# Altium schematic sheet aiao.SchDoc(aiao)
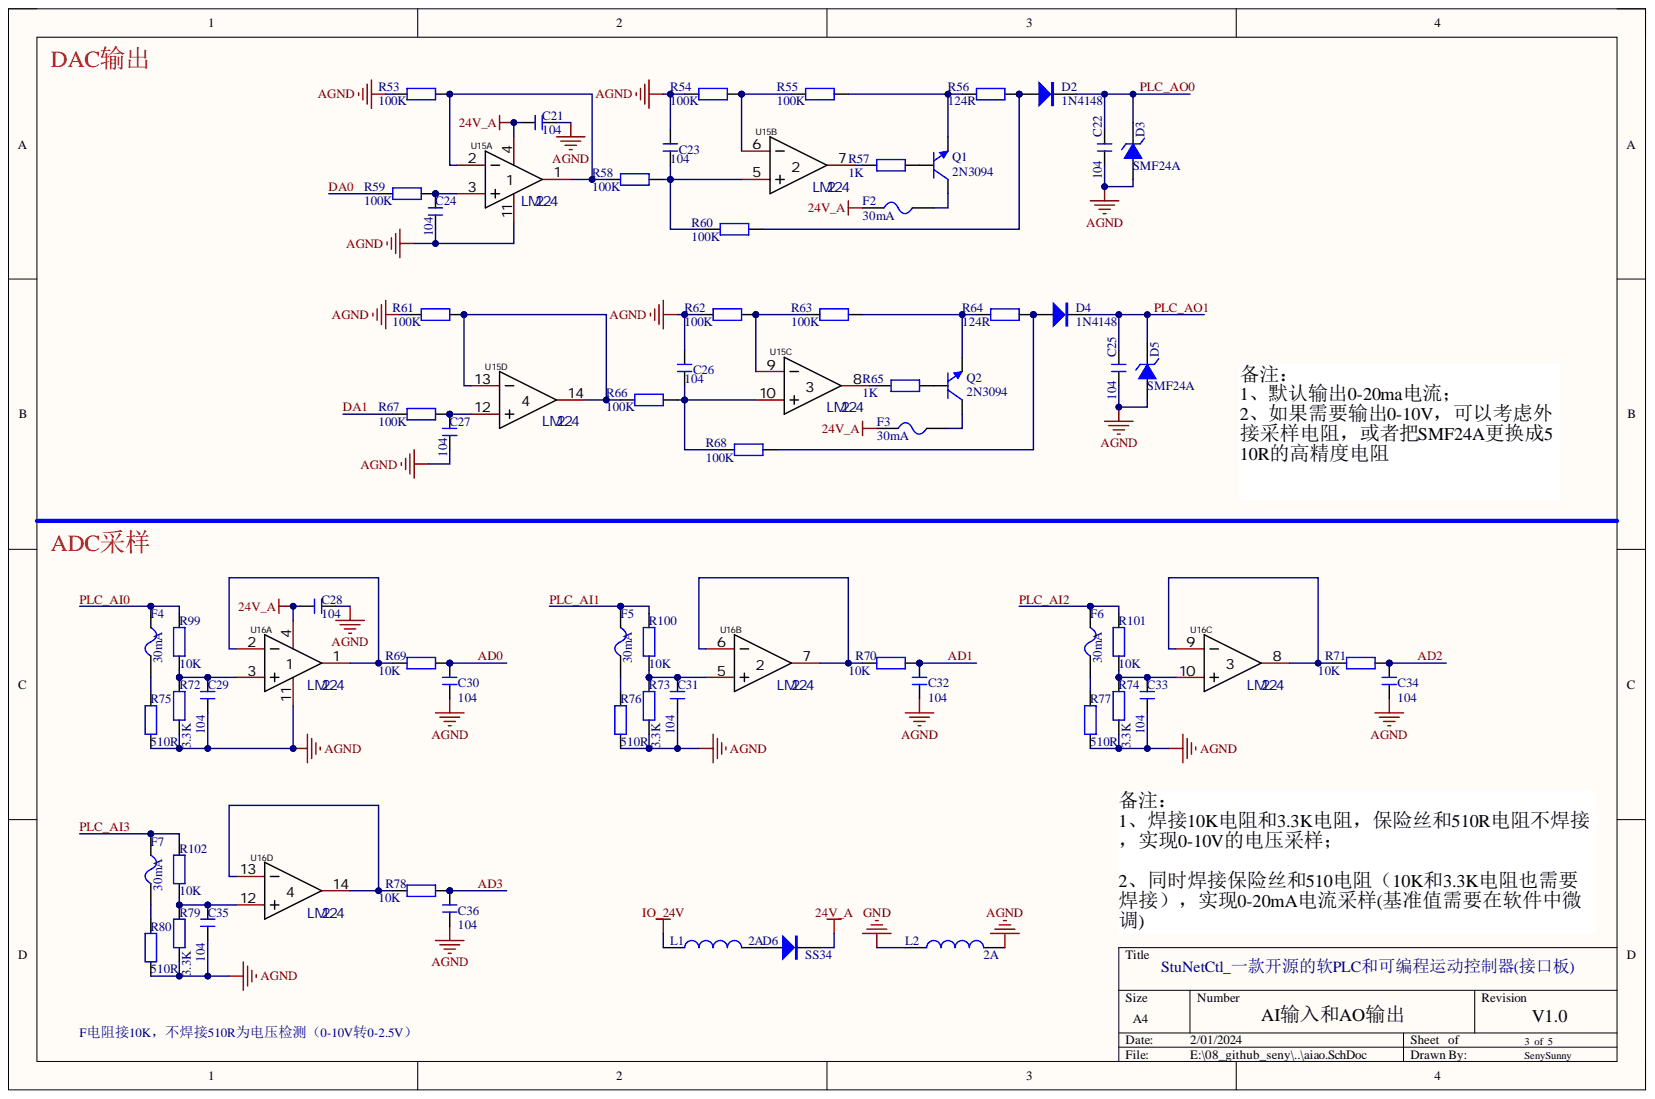
[diagram p0: aiao.SchDoc]

## Parts
C21  104  [C 0603]
C22  104  [C 0603]
C23  104  [C 0603]
C24  104  [C 0603]
C25  104  [C 0603]
C26  104  [C 0603]
C27  104  [C 0603]
C28  104  [C 0603]
C29  104  [C 0603]
C30  104  [C 0603]
C31  104  [C 0603]
C32  104  [C 0603]
C33  104  [C 0603]
C34  104  [C 0603]
C35  104  [C 0603]
C36  104  [C 0603]
D2  1N4148  [SOD-123F]
D3  SMF24A  [SOD-123]
D4  1N4148  [SOD-123F]
D5  SMF24A  [SOD-123]
D6  SS34  [SMA(DO214AC)]
F2  30mA  [FU 0805]
F3  30mA  [FU 0805]
F4  30mA  [FU 0805]
F5  30mA  [FU 0805]
F6  30mA  [FU 0805]
F7  30mA  [FU 0805]
L1  2A  [L 0805]
L2  2A  [L 0805]
Q1  2N3094  [SOT23-3N]
Q2  2N3094  [SOT23-3N]
R53  100K  [R 0603]
R54  100K  [R 0603]
R55  100K  [R 0603]
R56  124R  [R 1206]
R57  1K  [R 0603]
R58  100K  [R 0603]
R59  100K  [R 0603]
R60  100K  [R 0603]
R61  100K  [R 0603]
R62  100K  [R 0603]
R63  100K  [R 0603]
R64  124R  [R 1206]
R65  1K  [R 0603]
R66  100K  [R 0603]
R67  100K  [R 0603]
R68  100K  [R 0603]
R69  10K  [R 0603]
R70  10K  [R 0603]
R71  10K  [R 0603]
R72  3.3K  [R 0603]
R73  3.3K  [R 0603]
R74  3.3K  [R 0603]
R75  510R  [R 1206]
R76  510R  [R 1206]
R77  510R  [R 1206]
R78  10K  [R 0603]
R79  3.3K  [R 0603]
R80  510R  [R 1206]
R99  10K  [R 0603]
R100  10K  [R 0603]
R101  10K  [R 0603]
R102  10K  [R 0603]
U15  LM224  [SOP14_L]  (parts A,B,C,D)
U16  LM224  [SOP14_L]  (parts A,B,C,D)

## Nets
24V_A: C21.1 C28.1 D6.2 F2.2 F3.2 U15.4 U16.4
AGND: C21.2 C22.2 C23.1 C24.1 C25.2 C26.1 C27.1 C28.2 C29.1 C30.1 C31.1 C32.1 C33.1 C34.1 C35.1 C36.1 D3.1 D5.1 L2.2 R53.1 R54.1 R61.1 R62.1 R72.2 R73.2 R74.2 R75.2 R76.2 R77.2 R79.2 R80.2 U15.11 U16.11
GND: L2.1
IO_24V: L1.1
AD0: C30.2 R69.2
AD1: C32.2 R70.2
AD2: C34.2 R71.2
AD3: C36.2 R78.2
DA0: R59.1
DA1: R67.1
PLC_AI0: F4.2 R99.1
PLC_AI1: F5.2 R100.1
PLC_AI2: F6.2 R101.1
PLC_AI3: F7.2 R102.1
PLC_AO0: C22.1 D2.2 D3.2
PLC_AO1: C25.1 D4.2 D5.2
NetC23_2: C23.2 R58.2 R60.1 U15.5
NetC24_2: C24.2 R59.2 U15.3
NetC26_2: C26.2 R66.2 R68.1 U15.10
NetC27_2: C27.2 R67.2 U15.12
NetC29_2: C29.2 R72.1 R99.2 U16.3
NetC31_2: C31.2 R73.1 R100.2 U16.5
NetC33_2: C33.2 R74.1 R101.2 U16.10
NetC35_2: C35.2 R79.1 R102.2 U16.12
NetD2_1: D2.1 R56.2 R60.2
NetD4_1: D4.1 R64.2 R68.2
NetD6_1: D6.1 L1.2
NetF2_1: F2.1 Q1.3
NetF3_1: F3.1 Q2.3
NetF4_1: F4.1 R75.1
NetF5_1: F5.1 R76.1
NetF6_1: F6.1 R77.1
NetF7_1: F7.1 R80.1
NetQ1_1: Q1.1 R57.2
NetQ1_2: Q1.2 R55.2 R56.1
NetQ2_1: Q2.1 R65.2
NetQ2_2: Q2.2 R63.2 R64.1
NetR53_2: R53.2 R58.1 U15.1 U15.2
NetR54_2: R54.2 R55.1 U15.6
NetR57_1: R57.1 U15.7
NetR61_2: R61.2 R66.1 U15.13 U15.14
NetR62_2: R62.2 R63.1 U15.9
NetR65_1: R65.1 U15.8
NetR69_1: R69.1 U16.1 U16.2
NetR70_1: R70.1 U16.6 U16.7
NetR71_1: R71.1 U16.8 U16.9
NetR78_1: R78.1 U16.13 U16.14

## Library data of the parts
C21-C36 = C: 无极性贴片电容; 版权归属: 源创客; BOM名称: 无极性贴片电容; Component Kind: Standard; 学习资源: www.ooocke.com; Library Name: zblib_v1.IntLib
D2 D4 = FFM107: 快恢复整流二极管; BOM名称: 快恢复二极管; 版权归属: 源创客; Component Kind: Standard; 学习资源: www.ooocke.com; Library Name: zblib_v1.IntLib
D3 D5 = SMF6.0A: TVS二极管/SMF6.0A; BOM名称: 5.1V/1W稳压管; 版权归属: 源创客; Component Kind: Standard; 学习资源: www.ooocke.com; Library Name: zblib_v1.IntLib
D6 = SS14: 肖特基二极管; 属性: 40V/1A; 版权归属: 源创客; BOM名称: 肖特基二极管; Component Kind: Standard; 学习资源: www.ooocke.com; Library Name: zblib_v1.IntLib
F2-F7 = FU: 贴片保险丝; 版权归属: 源创客; BOM名称: 贴片保险丝; Component Kind: Standard; 学习资源: www.ooocke.com; Library Name: zblib_v1.IntLib
L1 L2 = L: 小功率贴片电感; 版权归属: 源创客; BOM名称: 小功率贴片电感; Component Kind: Standard; 学习资源: www.ooocke.com; Library Name: zblib_v1.IntLib
Q1 Q2 = 8050-SMD: 高频放大-NPN型; 属性: 40V 1.5A 1W 100MHZ; 版权归属: 源创客; BOM名称: 高频放大-NPN型; Component Kind: Standard; 学习资源: www.ooocke.com; Library Name: zblib_v1.IntLib
R53-R80 R99-R102 = R: 贴片电阻; 版权归属: 源创客; BOM名称: 贴片电阻; Component Kind: Standard; 学习资源: www.ooocke.com; Library Name: zblib_v1.IntLib
U15 U16 = LM324: 四路运算放大器; Manufacturer: ST(意法半导体); Add into BOM: yes; Amplifier Type: General Purpose; Device: LM324DT; Gain Bandwidth Product（GBP）: 1.3MHz; Iq per channel (Typ): 1.5mA; Number of Circuits: 4; Slew Rate（SR）: 0.4 V/us; Supply Voltage（Single）: 3V ~ 32V, ±1.5V ~ 16V; Component Kind: Standard; Convert to PCB: yes; Datasheet: https://atta.szlcsc.com/upload/public/pdf/source/20160408/1460100649718.pdf; JLCPCB Part Class: Basic Part; LCSC Part Name: LM324DT; Manufacturer Part: LM324DT; Name: LM324DT; Operating Temperature: 0°C ~ 70°C; Output Type: -; Supplier: LCSC; Supplier Footprint: SOIC-14_150mil; Supplier Part: C71035; Symbol: LM324DT; Library Name: zblib_v1.IntLib
